# Altium schematic sheet 7_EX_DEVICE.SchDoc
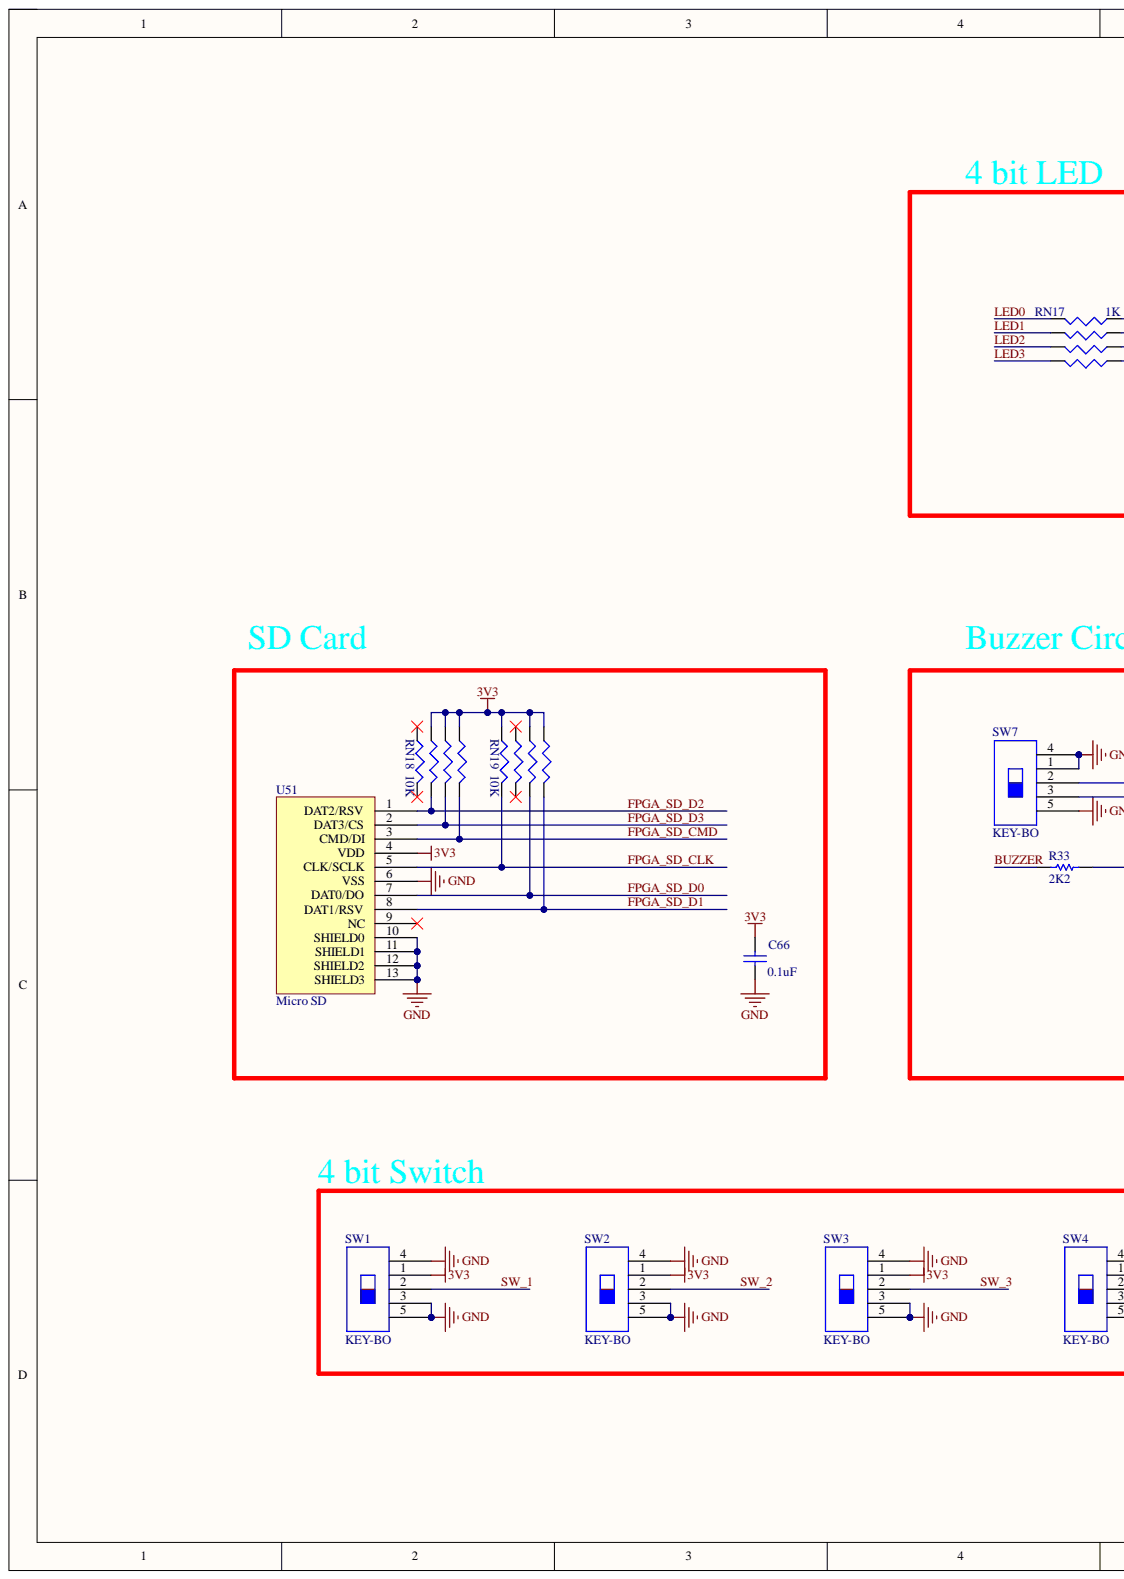
[diagram p0: 7_EX_DEVICE.SchDoc, left]
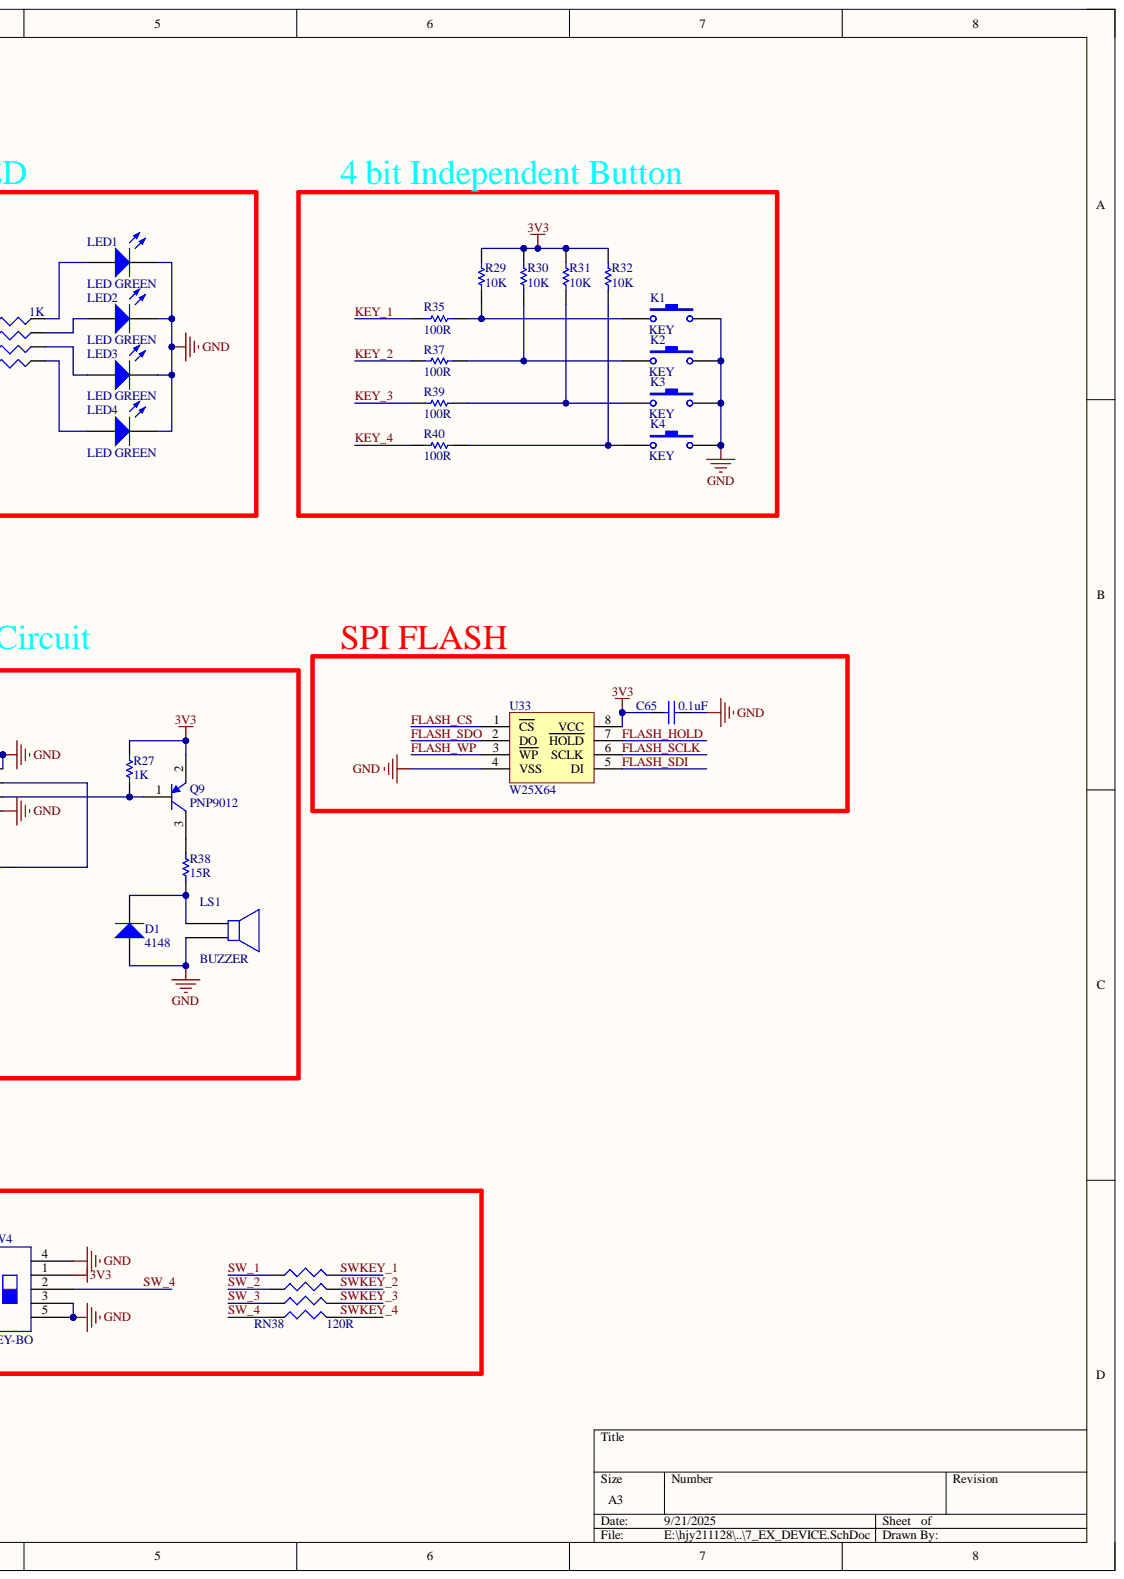
[diagram p1: 7_EX_DEVICE.SchDoc, right]

## Parts
C65  0.1uF  [0603]
C66  0.1uF  [0603]
D1  4148  [SOD80]
K1  KEY  [KEY_2]
K2  KEY  [KEY_2]
K3  KEY  [KEY_2]
K4  KEY  [KEY_2]
LED1  LED GREEN  [LED0805G]
LED2  LED GREEN  [LED0805G]
LED3  LED GREEN  [LED0805G]
LED4  LED GREEN  [LED0805G]
LS1  BUZZER  [SPEAK]
Q9  PNP9012  [SOT-23-3]
R27  1K  [0603]
R29  10K  [0603]
R30  10K  [0603]
R31  10K  [0603]
R32  10K  [0603]
R33  2K2  [0603]
R35  100R  [0603]
R37  100R  [0603]
R38  15R  [0603]
R39  100R  [0603]
R40  100R  [0603]
RN17  1K  [RN-8P]
RN18  10K  [RN-8P]
RN19  10K  [RN-8P]
RN38  120R  [RN-8P]
SW1  KEY-BO  [KEY_BODONG]
SW2  KEY-BO  [KEY_BODONG]
SW3  KEY-BO  [KEY_BODONG]
SW4  KEY-BO  [KEY_BODONG]
SW7  KEY-BO  [KEY_BODONG]
U33  W25X64  [SOIC-8W]
U51  Micro SD  [MICROSD-9PIN]

## Nets
3V3: C65.1 C66.1 Q9.2 R27.1 R29.2 R30.2 R31.2 R32.2 RN18.6 RN18.7 RN18.8 RN19.5 RN19.7 RN19.8 SW1.1 SW2.1 SW3.1 SW4.1 U33.8(VCC) U51.4(VDD)
GND: C65.2 C66.2 D1.1 K1.2 K2.2 K3.2 K4.2 LED1.2 LED2.2 LED3.2 LED4.2 LS1.2 SW1.3 SW1.4 SW1.5 SW2.3 SW2.4 SW2.5 SW3.3 SW3.4 SW3.5 SW4.3 SW4.4 SW4.5 SW7.1 SW7.4 SW7.5 U33.4(VSS) U51.6(VSS) U51.10(SHIELD0) U51.11(SHIELD1) U51.12(SHIELD2) U51.13(SHIELD3)
BUZZER: R33.2
FLASH_CS: U33.1(CS)
FLASH_HOLD: U33.7(HOLD)
FLASH_SCLK: U33.6(SCLK)
FLASH_SDI: U33.5(DI)
FLASH_SDO: U33.2(DO)
FLASH_WP: U33.3(WP)
FPGA_SD_CLK: RN19.4 U51.5(CLK/SCLK)
FPGA_SD_CMD: RN18.1 U51.3(CMD/DI)
FPGA_SD_D0: RN19.2 U51.7(DAT0/DO)
FPGA_SD_D1: RN19.1 U51.8(DAT1/RSV)
FPGA_SD_D2: RN18.3 U51.1(DAT2/RSV)
FPGA_SD_D3: RN18.2 U51.2(DAT3/CS)
KEY_1: R35.2
KEY_2: R37.2
KEY_3: R39.2
KEY_4: R40.2
LED0: RN17.5
LED1: RN17.6
LED2: RN17.7
LED3: RN17.8
SW_1: RN38.1 SW1.2
SW_2: RN38.2 SW2.2
SW_3: RN38.3 SW3.2
SW_4: RN38.4 SW4.2
SWKEY_1: RN38.8
SWKEY_2: RN38.7
SWKEY_3: RN38.6
SWKEY_4: RN38.5
NetD1_2: D1.2 LS1.1 R38.2
NetK1_1: K1.1 R29.1 R35.1
NetK2_1: K2.1 R30.1 R37.1
NetK3_1: K3.1 R31.1 R39.1
NetK4_1: K4.1 R32.1 R40.1
NetLED1_1: LED1.1 RN17.4
NetLED2_1: LED2.1 RN17.3
NetLED3_1: LED3.1 RN17.2
NetLED4_1: LED4.1 RN17.1
NetQ9_1: Q9.1 R27.2 SW7.3
NetQ9_3: Q9.3 R38.1
NetR33_1: R33.1 SW7.2

Not connected: RN18 4 5; RN19 3 6; U51 9(NC)

## Library data of the parts
C65 C66 = CAP: Capacitor; Value: 104; Component Kind: Standard; LatestRevisionDate: 17-Jul-2002; LatestRevisionNote: Re-released for DXP Platform.; PackageReference: RAD-0.3; Published: 8-Jun-2000; Library Name: cong.IntLib; Publisher: Altium Limited
D1 = 1N4007: High Conductance Fast Diode; Code_JEDEC: DO-204-AH; Component Kind: Standard; ComponentLink1Description: Manufacturer Link; ComponentLink1URL: http://www.fairchildsemi.com/; ComponentLink2Description: Datasheet; ComponentLink2URL: http://www.fairchildsemi.com/ds/1N%2F1N4448.pdf; DatasheetDocument: 1997; LatestRevisionDate: 17-Jul-2002; LatestRevisionNote: Re-released for DXP Platform.; Library Name: My Footprint.SchLib; PackageReference: DO-35; Published: 8-Jun-2000; Publisher: Altium Limited
K1-K4 = KEY_2: Switch; Component Kind: Standard; LatestRevisionDate: 17-Jul-2002; LatestRevisionNote: Re-released for DXP Platform.; PackageReference: SPST-2; Published: 24-Mar-1999; Library Name: cong.IntLib; Publisher: Altium Limited
LED1-LED4 = LED2: Typical RED, GREEN, YELLOW, AMBER GaAs LED; Component Kind: Standard; Library Name: WARSHIP_SCH.lib
LS1 = SPEAKER: Component Kind: Standard
Q9 = PNP2: 贴片; Code_JEDEC: SOT-23; Component Kind: Standard; LatestRevisionDate: 17-Jul-2002; LatestRevisionNote: Re-released for DXP Platform.; PackageReference: SOT-23B; Published: 8-Jun-2000; Library Name: cong.IntLib; Publisher: Altium Limited
R27 = RES: Resistor; Component Kind: Standard; Library Name: My Footprint.SchLib; Published: 8-Jun-2000; Revision: July-2002: Re-released for DXP Platform.; Value: 1K; Publisher: Altium Limited
R29-R33 R35 R37-R40 = RES: Resistor; Value: 1K; Component Kind: Standard; Library Name: My Footprint.SchLib; Published: 8-Jun-2000; Revision: July-2002: Re-released for DXP Platform.; Publisher: Altium Limited
RN17-RN19 RN38 = RN_Dup1: Component Kind: Standard
U33 = GD25Q80: Component Kind: Standard; Library Name: JJHLIB.IntLib
SW1-SW4 SW7 = KEY-BO: Component Kind: Standard; Library Name: Schlib.SchLib
U51 = Micro SD: Component Kind: Standard; Library Name: Schlib.SchLib
